# Altium schematic sheet debug.SchDoc(debug)
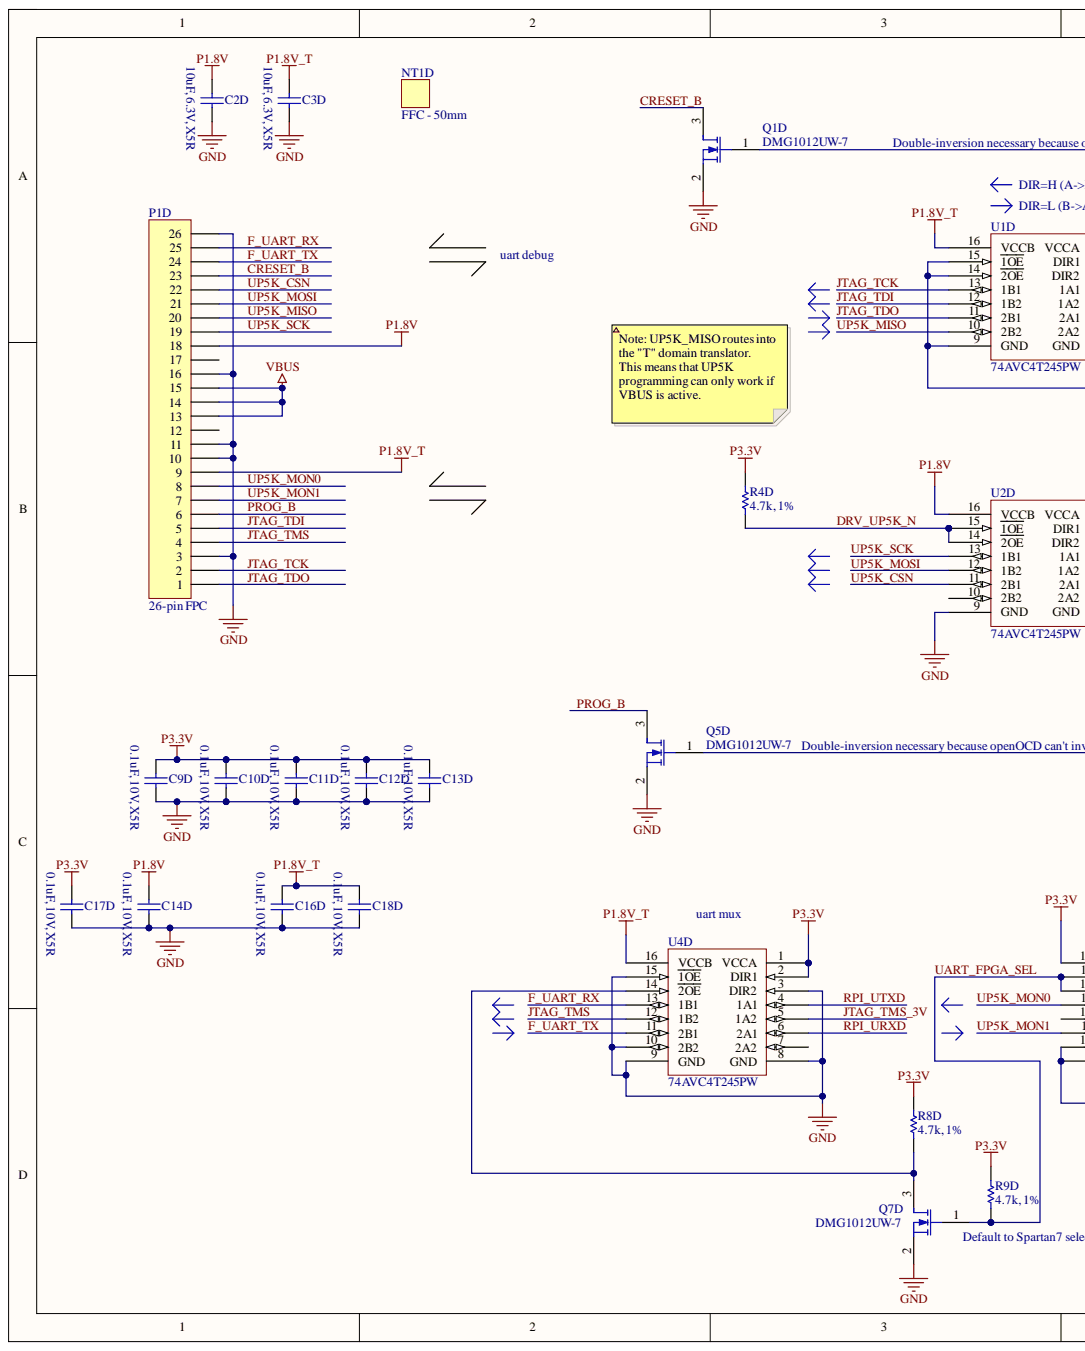
[diagram p0: debug.SchDoc, left]
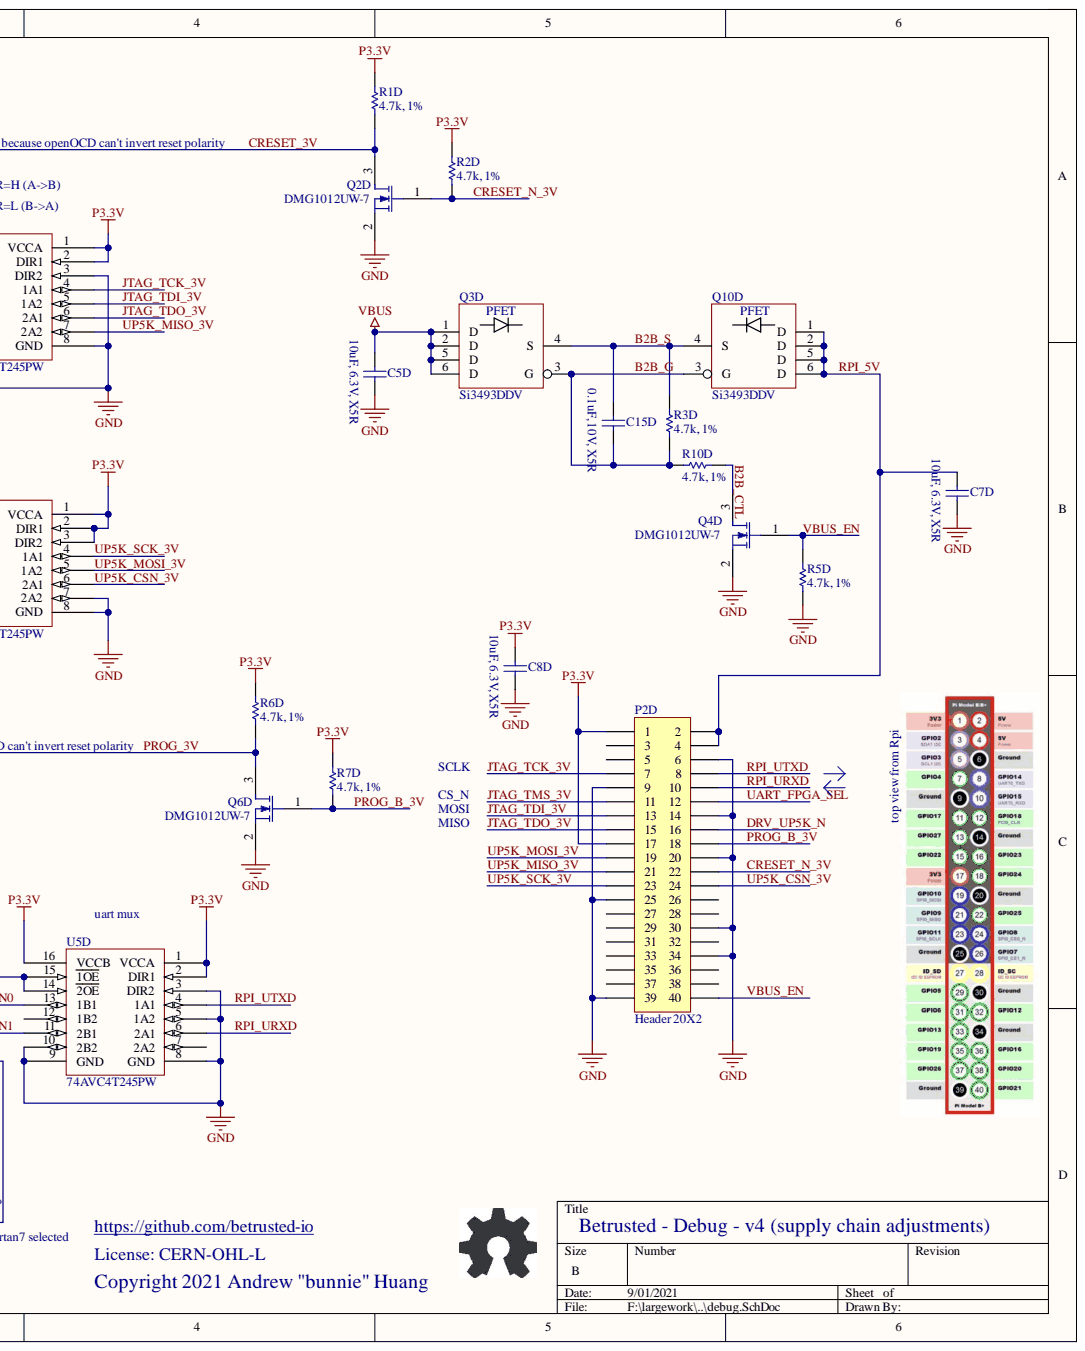
[diagram p1: debug.SchDoc, right]

## Parts
C2D  10uF, 6.3V, X5R  [B_CAP0603]
C3D  10uF, 6.3V, X5R  [B_CAP0603]
C5D  10uF, 6.3V, X5R  [B_CAP0603]
C7D  10uF, 6.3V, X5R  [B_CAP0603]
C8D  10uF, 6.3V, X5R  [B_CAP0603]
C9D  0.1uF, 10V, X5R  [B_CAP0402]
C10D  0.1uF, 10V, X5R  [B_CAP0402]
C11D  0.1uF, 10V, X5R  [B_CAP0402]
C12D  0.1uF, 10V, X5R  [B_CAP0402]
C13D  0.1uF, 10V, X5R  [B_CAP0402]
C14D  0.1uF, 10V, X5R  [B_CAP0402]
C15D  0.1uF, 10V, X5R  [B_CAP0402]
C16D  0.1uF, 10V, X5R  [B_CAP0402]
C17D  0.1uF, 10V, X5R  [B_CAP0402]
C18D  0.1uF, 10V, X5R  [B_CAP0402]
NT1D  FFC - 50mm
P1D  26-pin FPC  [HRS_FH34SRJ-26S-0.5SH(50)]
P2D  Header 20X2  [SAMTEC_SSW-120-01-F-D]
Q1D  DMG1012UW-7  [SOT323-3AN]
Q2D  DMG1012UW-7  [SOT323-3AN]
Q3D  Si3493DDV  [SOT26A-6N]
Q4D  DMG1012UW-7  [SOT323-3AN]
Q5D  DMG1012UW-7  [SOT323-3AN]
Q6D  DMG1012UW-7  [SOT323-3AN]
Q7D  DMG1012UW-7  [SOT323-3AN]
Q10D  Si3493DDV  [SOT26A-6N]
R1D  4.7k, 1%  [B_RES0402]
R2D  4.7k, 1%  [B_RES0402]
R3D  4.7k, 1%  [B_RES0402]
R4D  4.7k, 1%  [B_RES0402]
R5D  4.7k, 1%  [B_RES0402]
R6D  4.7k, 1%  [B_RES0402]
R7D  4.7k, 1%  [B_RES0402]
R8D  4.7k, 1%  [B_RES0402]
R9D  4.7k, 1%  [B_RES0402]
R10D  4.7k, 1%  [B_RES0402]
U1D  74AVC4T245PW  [TSOP65P640-16AN]
U2D  74AVC4T245PW  [TSOP65P640-16AN]
U4D  74AVC4T245PW  [TSOP65P640-16AN]
U5D  74AVC4T245PW  [TSOP65P640-16AN]

## Nets
GND: C2D.2 C3D.2 C5D.2 C7D.2 C8D.2 C9D.2 C10D.2 C11D.2 C12D.2 C13D.2 C14D.2 C16D.2 C17D.2 C18D.2 P1D.3 P1D.10 P1D.11 P1D.16 P1D.26 P2D.6 P2D.9 P2D.14 P2D.20 P2D.25 P2D.30 P2D.34 P2D.39 Q1D.2 Q2D.2 Q4D.2 Q5D.2 Q6D.2 Q7D.2 R5D.1 U1D.3(DIR2) U1D.8(GND) U1D.9(GND) U1D.14(2OE) U1D.15(1OE) U2D.7(2A2) U2D.8(GND) U2D.9(GND) U4D.3(DIR2) U4D.8(GND) U4D.9(GND) U4D.10(2B2) U4D.15(1OE) U5D.3(DIR2) U5D.5(1A2) U5D.8(GND) U5D.9(GND) U5D.10(2B2)
P1.8V: C2D.1 C14D.1 P1D.18 U2D.16(VCCB)
P1.8V_T: C3D.1 C16D.1 C18D.1 P1D.9 U1D.16(VCCB) U4D.16(VCCB)
P3.3V: C8D.1 C9D.1 C10D.1 C11D.1 C12D.1 C13D.1 C17D.1 P2D.1 P2D.17 R1D.2 R2D.2 R4D.2 R6D.2 R7D.2 R8D.2 R9D.2 U1D.1(VCCA) U1D.2(DIR1) U2D.1(VCCA) U2D.2(DIR1) U2D.3(DIR2) U4D.1(VCCA) U4D.2(DIR1) U5D.1(VCCA) U5D.2(DIR1) U5D.16(VCCB)
VBUS: C5D.1 P1D.13 P1D.14 P1D.15 Q3D.1(D) Q3D.2(D) Q3D.5(D) Q3D.6(D)
B2B_CTL: Q4D.3 R10D.2
B2B_G: C15D.2 Q3D.3(G) Q10D.3(G) R3D.2 R10D.1
B2B_S: C15D.1 Q3D.4(S) Q10D.4(S) R3D.1
CRESET_3V: Q1D.1 Q2D.3 R1D.1
CRESET_B: P1D.23 Q1D.3
CRESET_N_3V: P2D.22 Q2D.1 R2D.1
DRV_UP5K_N: P2D.16 R4D.1 U2D.14(2OE) U2D.15(1OE)
F_UART_RX: P1D.25 U4D.13(1B1)
F_UART_TX: P1D.24 U4D.11(2B1)
JTAG_TCK: P1D.2 U1D.13(1B1)
JTAG_TCK_3V: P2D.7 U1D.4(1A1)
JTAG_TDI: P1D.5 U1D.12(1B2)
JTAG_TDI_3V: P2D.13 U1D.5(1A2)
JTAG_TDO: P1D.1 U1D.11(2B1)
JTAG_TDO_3V: P2D.15 U1D.6(2A1)
JTAG_TMS: P1D.4 U4D.12(1B2)
JTAG_TMS_3V: P2D.11 U4D.5(1A2)
PROG_3V: Q5D.1 Q6D.3 R6D.1
PROG_B: P1D.6 Q5D.3
PROG_B_3V: P2D.18 Q6D.1 R7D.1
RPI_5V: C7D.1 P2D.2 P2D.4 Q10D.1(D) Q10D.2(D) Q10D.5(D) Q10D.6(D)
RPI_URXD: P2D.10 U4D.6(2A1) U5D.6(2A1)
RPI_UTXD: P2D.8 U4D.4(1A1) U5D.4(1A1)
UART_FPGA_SEL: P2D.12 Q7D.1 R9D.1 U5D.14(2OE) U5D.15(1OE)
UP5K_CSN: P1D.22 U2D.11(2B1)
UP5K_CSN_3V: P2D.24 U2D.6(2A1)
UP5K_MISO: P1D.20 U1D.10(2B2)
UP5K_MISO_3V: P2D.21 U1D.7(2A2)
UP5K_MON0: P1D.8 U5D.13(1B1)
UP5K_MON1: P1D.7 U5D.11(2B1)
UP5K_MOSI: P1D.21 U2D.12(1B2)
UP5K_MOSI_3V: P2D.19 U2D.5(1A2)
UP5K_SCK: P1D.19 U2D.13(1B1)
UP5K_SCK_3V: P2D.23 U2D.4(1A1)
VBUS_EN: P2D.40 Q4D.1 R5D.2
NetQ7D_3: Q7D.3 R8D.1 U4D.14(2OE)

Not connected: P1D 12 17; P2D 3 5 26 27 28 29 31 32 33 35 36 37 38; U2D 10(2B2); U4D 7(2A2); U5D 7(2A2) 12(1B2)

## Library data of the parts
C2D C3D C5D C7D C8D = Cap Semi: Capacitor (Semiconductor SIM Model); MPN: CL10A106MQ8NNNC; MYPN: C-106-6.3-X5R-0603; VENDOR: Samsung; Component Kind: Standard; Library Name: Miscellaneous Devices.IntLib; Signal Integrity: Cap Semi; Simulation: CAP
C9D C10D C11D C12D C13D C14D C15D C16D C17D C18D = Cap Semi: Capacitor (Semiconductor SIM Model); MPN: CL05A104KP5NNNC; MYPN: C-104-10-X5R-0402; VENDOR: Samsung; Component Kind: Standard; Library Name: Miscellaneous Devices.IntLib; Signal Integrity: Cap Semi; Simulation: CAP
NT1D = NOTE: Placeholder for non-board BOM items; MPN: FJH-26-D-02.00-4; MYPN: NT-FFC-26x0.5mm-50mm; VENDOR: Samtec; Component Kind: Standard; Library Name: commonsch.lib
P1D = FPC-26: 26 Pin FPC header; MPN: FH34SRJ-26S-0.5SH(99); MYPN: J-FPC-0.5mm-26-0.3mm-T/B; VENDOR: Hirose; Component Kind: Standard; Library Name: commonsch-new.SchLib
P2D = Header 20X2: Header, 20-Pin, Dual row; MPN: CS25402-40G-S85-C; MYPN: P-SSW-120-01-F-D; VENDOR: Kaweei; Component Kind: Standard; LatestRevisionDate: 17-Jul-2002; LatestRevisionNote: Re-released for DXP Platform.; Library Name: Miscellaneous Connectors.IntLib; Signal Integrity: Connector; Publisher: Altium Limited
Q1D Q2D Q4D Q5D Q6D Q7D = MOSFET-N: N-Channel MOSFET; MYPN: Q-DMG1012UW-7; VENDOR: Diodes Inc; Component Kind: Standard; LatestRevisionDate: 17-Jul-2002; LatestRevisionNote: Re-released for DXP Platform.; Library Name: Miscellaneous Devices.IntLib; MPN: DMG1012UW-7; PackageReference: E3; PackageVersion: 1995/1996; Published: 8-Jun-2000; Simulation: NMOS; Publisher: Altium Limited
Q3D Q10D = Si3493DDV: P-channel 20V 8A 32mOhm @ -2.5V; MPN: SI3493DDV-T1-GE3; MYPN: Q-SI3493DDV-T1-GE3; VENDOR: Vishay Siliconix; Component Kind: Standard; Library Name: commonsch-new.SchLib
R1D R2D R3D R4D R5D R6D R7D R8D R9D R10D = Res1: Resistor; MPN: ANY; MYPN: R-472-1P-1_16W-0402; Component Kind: Standard; Library Name: Miscellaneous Devices.IntLib; Signal Integrity: Res; Simulation: RESISTOR; VENDOR: ANY
U1D U2D U4D U5D = 74AVC4T245: 4-bit dual supply translating transceiver with configurable voltage translation; 3-state; standard pinout; MYPN: U-74AVC4T245PW; VENDOR: Nexperia; Component Kind: Standard; Library Name: commonsch-new.SchLib; MPN: 74AVC4T245PW
